# Altium schematic sheet LIDO-HW.100.OutputStage.SchDoc(LIDO-HW.100.OutputStage)
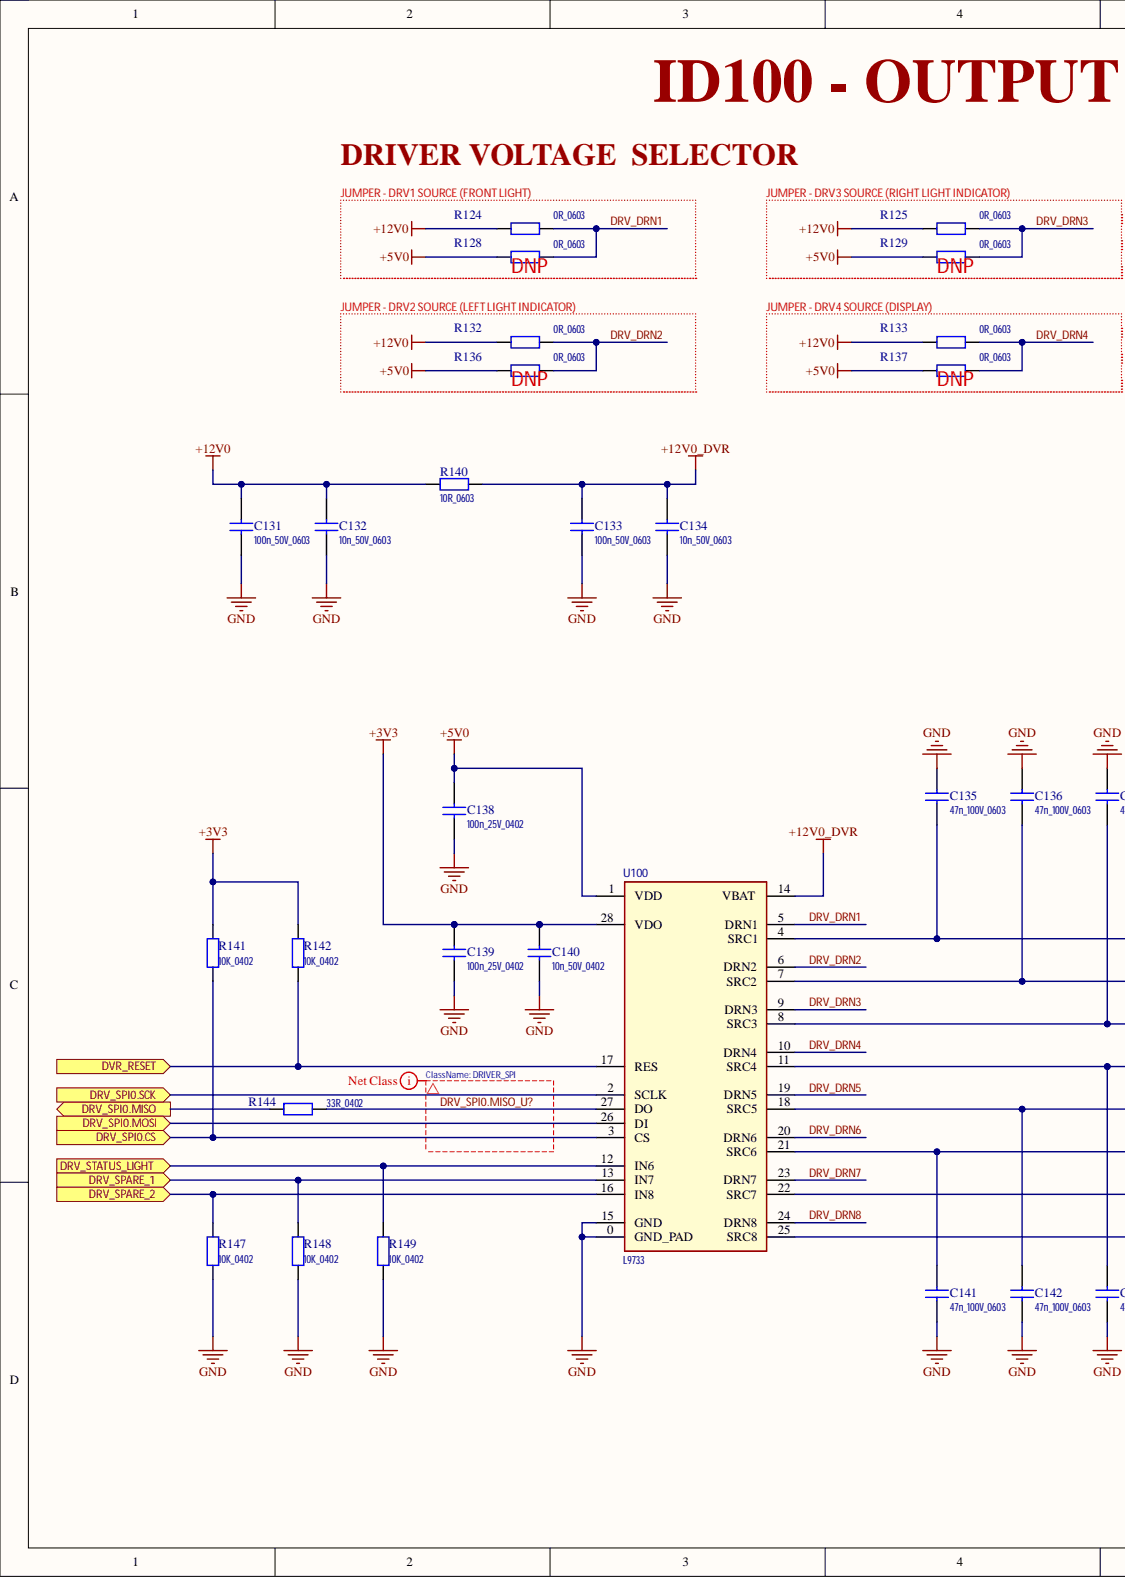
[diagram p0: LIDO-HW.100.OutputStage.SchDoc, left]
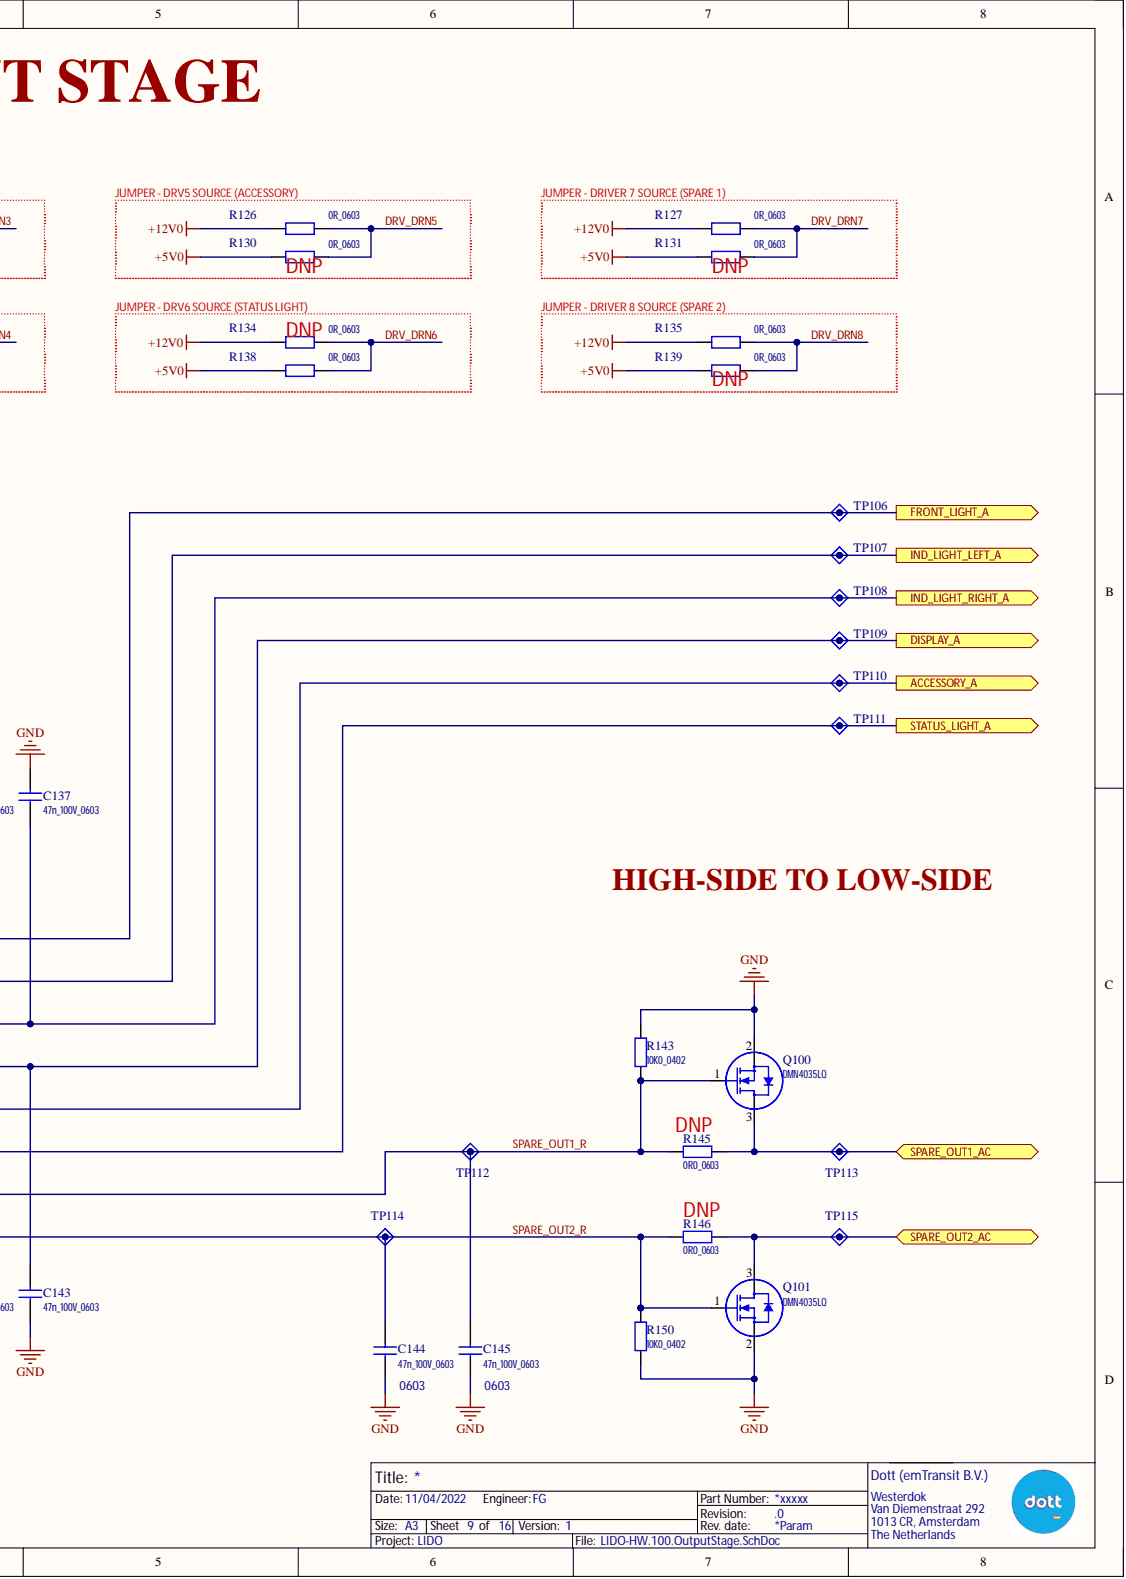
[diagram p1: LIDO-HW.100.OutputStage.SchDoc, right]

## Parts
C131  100n_50V_0603
C132  10n_50V_0603
C133  100n_50V_0603
C134  10n_50V_0603
C135  47n_100V_0603
C136  47n_100V_0603
C137  47n_100V_0603
C138  100n_25V_0402
C139  100n_25V_0402
C140  10n_50V_0402
C141  47n_100V_0603
C142  47n_100V_0603
C143  47n_100V_0603
C144  47n_100V_0603
C145  47n_100V_0603
Q100  DMN4035LQ
Q101  DMN4035LQ
R124  0R_0603
R125  0R_0603
R126  0R_0603
R127  0R_0603
R128  0R_0603
R129  0R_0603
R130  0R_0603
R131  0R_0603
R132  0R_0603
R133  0R_0603
R134  0R_0603
R135  0R_0603
R136  0R_0603
R137  0R_0603
R138  0R_0603
R139  0R_0603
R140  10R_0603
R141  10K_0402
R142  10K_0402
R143  10K0_0402
R144  33R_0402
R145  0R0_0603
R146  0R0_0603
R147  10K_0402
R148  10K_0402
R149  10K_0402
R150  10K0_0402
TP106  TestPoint_A  (value not drawn)  (no BOM)
TP107  TestPoint_A  (value not drawn)  (no BOM)
TP108  TestPoint_A  (value not drawn)  (no BOM)
TP109  TestPoint_A  (value not drawn)  (no BOM)
TP110  TestPoint_A  (value not drawn)  (no BOM)
TP111  TestPoint_A  (value not drawn)  (no BOM)
TP112  TestPoint_A  (value not drawn)  (no BOM)
TP113  TestPoint_A  (value not drawn)  (no BOM)
TP114  TestPoint_A  (value not drawn)  (no BOM)
TP115  TestPoint_A  (value not drawn)  (no BOM)
U100  L9733

## Ports
ACCESSORY_A, DISPLAY_A, DRV_SPARE_1, DRV_SPARE_2, DRV_SPI0.CS, DRV_SPI0.MISO, DRV_SPI0.MOSI, DRV_SPI0.SCK, DRV_STATUS_LIGHT, DVR_RESET, FRONT_LIGHT_A, IND_LIGHT_LEFT_A, IND_LIGHT_RIGHT_A, SPARE_OUT1_AC, SPARE_OUT2_AC, STATUS_LIGHT_A

## Nets
+3V3: C139.1 C140.1 R141.1 R142.1 U100.28(VDO)
+5V0: C138.1 R128.1 R129.1 R130.1 R131.1 R136.1 R137.1 R138.1 R139.1 U100.1(VDD)
+12V0: C131.1 C132.1 R124.1 R125.1 R126.1 R127.1 R132.1 R133.1 R134.1 R135.1 R140.2
+12V0_DVR: C133.1 C134.1 R140.1 U100.14(VBAT)
GND: C131.2 C132.2 C133.2 C134.2 C135.1 C136.1 C137.1 C138.2 C139.2 C140.2 C141.2 C142.2 C143.2 C144.2 C145.2 Q100.2 Q101.2 R143.2 R147.2 R148.2 R149.2 R150.2 U100.0(GND_PAD) U100.15(GND)
ACCESSORY_A: C142.1 TP110.1 U100.18(SRC5) ; port ACCESSORY_A
DISPLAY_A: C143.1 TP109.1 U100.11(SRC4) ; port DISPLAY_A
DRV_DRN1: R124.2 R128.2 U100.5(DRN1)
DRV_DRN2: R132.2 R136.2 U100.6(DRN2)
DRV_DRN3: R125.2 R129.2 U100.9(DRN3)
DRV_DRN4: R133.2 R137.2 U100.10(DRN4)
DRV_DRN5: R126.2 R130.2 U100.19(DRN5)
DRV_DRN6: R134.2 R138.2 U100.20(DRN6)
DRV_DRN7: R127.2 R131.2 U100.23(DRN7)
DRV_DRN8: R135.2 R139.2 U100.24(DRN8)
DRV_SPARE_1: R148.1 U100.13(IN7) ; port DRV_SPARE_1
DRV_SPARE_2: R147.1 U100.16(IN8) ; port DRV_SPARE_2
DRV_SPI0.CS: R141.2 U100.3(CS) ; port DRV_SPI0.CS
DRV_SPI0.MISO: R144.2 ; port DRV_SPI0.MISO
DRV_SPI0.MISO_U?: R144.1 U100.27(DO)
DRV_SPI0.MOSI: U100.26(DI) ; port DRV_SPI0.MOSI
DRV_SPI0.SCK: U100.2(SCLK) ; port DRV_SPI0.SCK
DRV_STATUS_LIGHT: R149.1 U100.12(IN6) ; port DRV_STATUS_LIGHT
DVR_RESET: R142.2 U100.17(RES) ; port DVR_RESET
FRONT_LIGHT_A: C135.2 TP106.1 U100.4(SRC1) ; port FRONT_LIGHT_A
IND_LIGHT_LEFT_A: C136.2 TP107.1 U100.7(SRC2) ; port IND_LIGHT_LEFT_A
IND_LIGHT_RIGHT_A: C137.2 TP108.1 U100.8(SRC3) ; port IND_LIGHT_RIGHT_A
SPARE_OUT1_AC: Q100.3 R145.1 TP113.1 ; port SPARE_OUT1_AC
SPARE_OUT1_R: C145.1 Q100.1 R143.1 R145.2 TP112.1 U100.22(SRC7)
SPARE_OUT2_AC: Q101.3 R146.1 TP115.1 ; port SPARE_OUT2_AC
SPARE_OUT2_R: C144.1 Q101.1 R146.2 R150.1 TP114.1 U100.25(SRC8)
STATUS_LIGHT_A: C141.1 TP111.1 U100.21(SRC6) ; port STATUS_LIGHT_A

## Library data of the parts
C131-C145 = Ceramic: Ceramic CAP Symbol; Component Kind: Standard; Library Name: 01_Capacitors.SchLib
Q100 Q101 = MOSFET_N_WD_1G_2S_3D: N-Channel MOSFET with Diode; Component Kind: Standard; Library Name: 07_Transistors.SchLib
R124-R150 = Resistor: Resistor Symbol; Component Kind: Standard; Library Name: 02_Resistors.SchLib
TP106-TP115 = TestPoint_A: Test Point Symbol verion A; 00_Name: TP_XXmm; 01_Diameter: 1mm; Library Name: 31_MFG_Elements.SchLib
U100 = L9733_A: Power Switch/Driver 1:8 N-Channel 1A PowerSSO-28 Exposed Pad; Component Kind: Standard; Library Name: 15_IC_PMIC.SchLib
